# Altium schematic sheet [03] - MCU and Conn.SchDoc
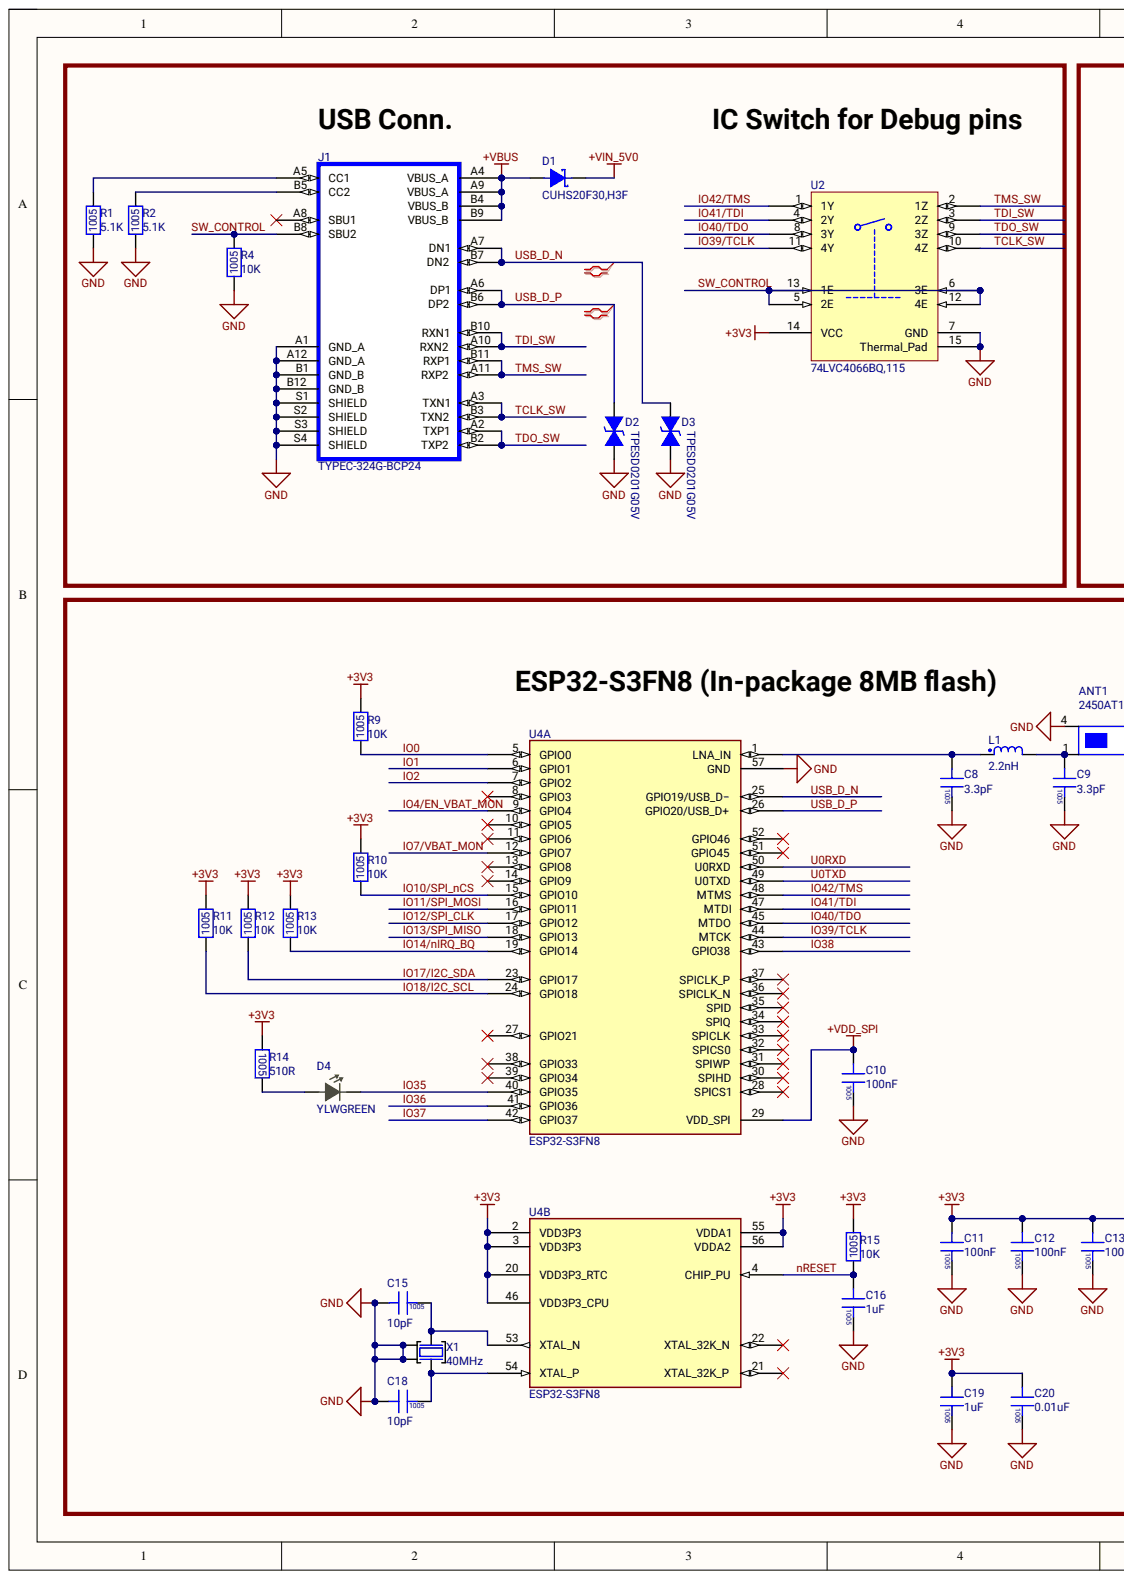
[diagram p0: [03] - MCU and Conn.SchDoc, left]
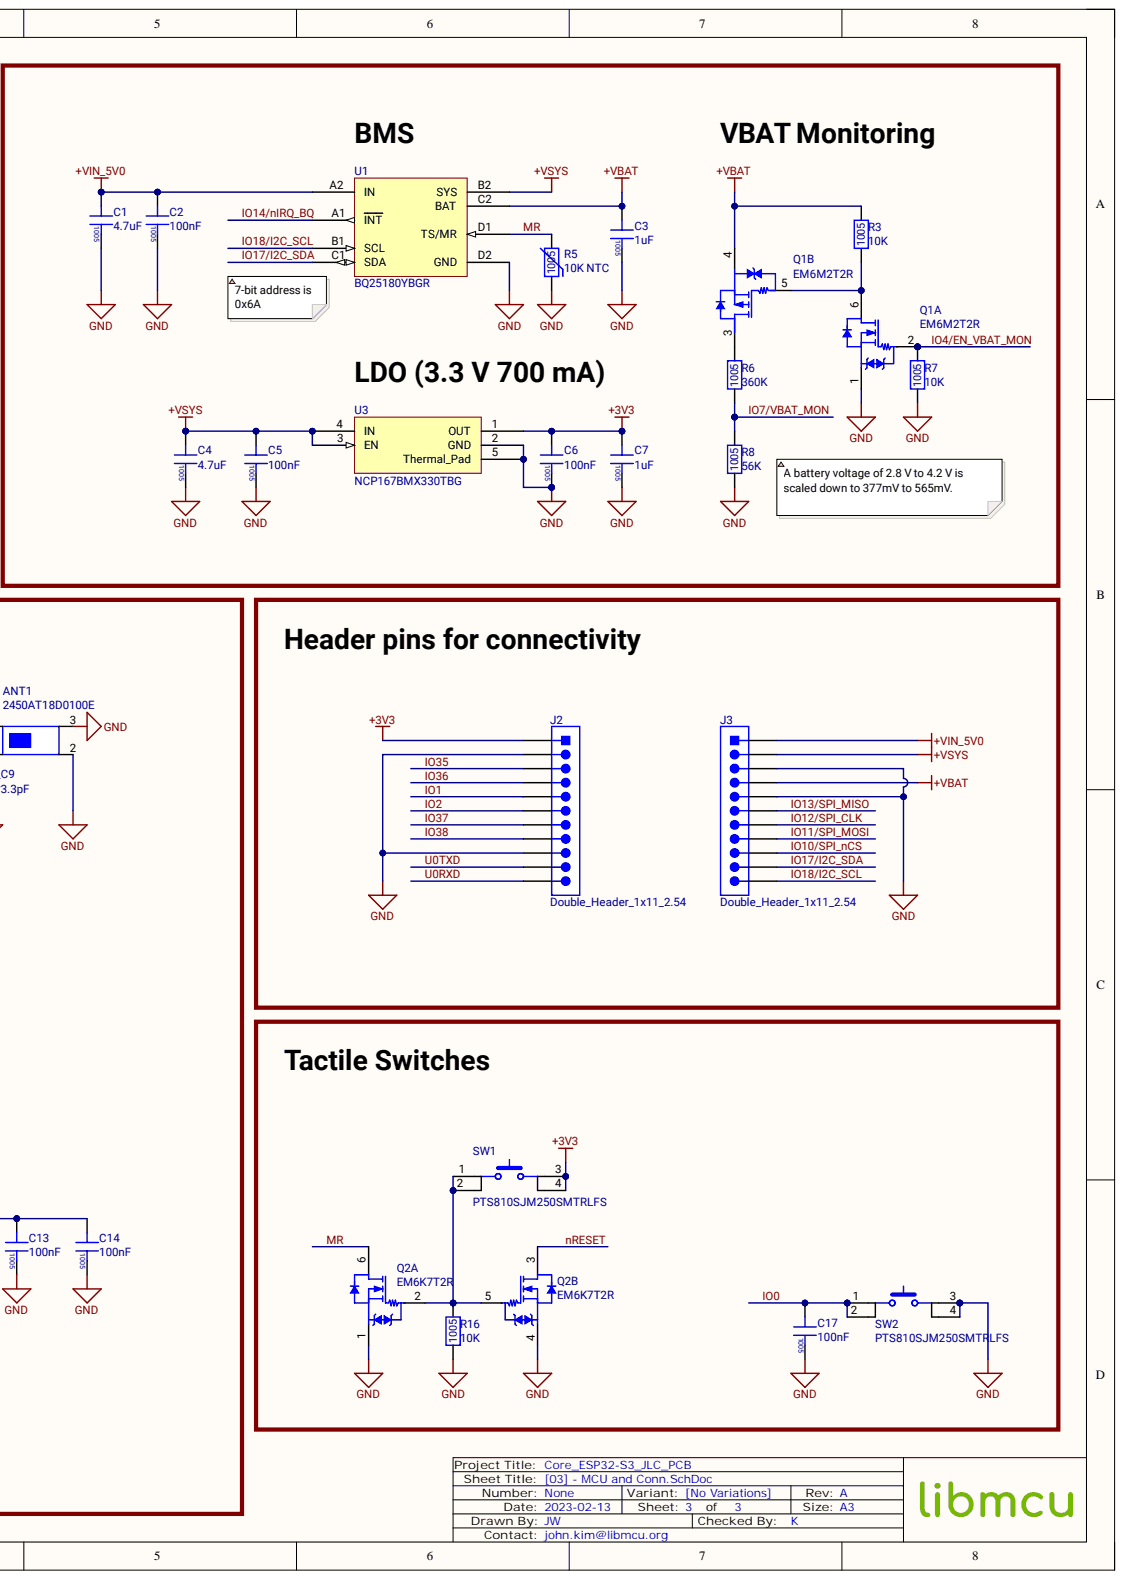
[diagram p1: [03] - MCU and Conn.SchDoc, right]

## Parts
ANT1  2450AT18D0100E  [2450AT18D0100E]
C1  4.7uF  Case Code (Metric): 1005  [CAPC1005X06N]
C2  100nF  Case Code (Metric): 1005  [CAPC1005X06N]
C3  1uF  Case Code (Metric): 1005  [CAPC1005X06N]
C4  4.7uF  Case Code (Metric): 1005  [CAPC1005X06N]
C5  100nF  Case Code (Metric): 1005  [CAPC1005X06N]
C6  100nF  Case Code (Metric): 1005  [CAPC1005X06N]
C7  1uF  Case Code (Metric): 1005  [CAPC1005X06N]
C8  3.3pF  Case Code (Metric): 1005  [CAPC1005X06N]
C9  3.3pF  Case Code (Metric): 1005  [CAPC1005X06N]
C10  100nF  Case Code (Metric): 1005  [CAPC1005X06N]
C11  100nF  Case Code (Metric): 1005  [CAPC1005X06N]
C12  100nF  Case Code (Metric): 1005  [CAPC1005X06N]
C13  100nF  Case Code (Metric): 1005  [CAPC1005X06N]
C14  100nF  Case Code (Metric): 1005  [CAPC1005X06N]
C15  10pF  Case Code (Metric): 1005  [CAPC1005X06N]
C16  1uF  Case Code (Metric): 1005  [CAPC1005X06N]
C17  100nF  Case Code (Metric): 1005  [CAPC1005X06N]
C18  10pF  Case Code (Metric): 1005  [CAPC1005X06N]
C19  1uF  Case Code (Metric): 1005  [CAPC1005X06N]
C20  0.01uF  Case Code (Metric): 1005  [CAPC1005X06N]
D1  CUHS20F30,H3F  [US2H-DIODE]
D2  TPESD0201G05V  [DIOC0603X03N]
D3  TPESD0201G05V  [DIOC0603X03N]
D4  YLWGREEN  [LDIOC1608X08N]
J1  TYPEC-324G-BCP24  [KUSBX-SMT3-CS-BTR_KYC]
J2  Double_Header_1x11_2.54  [1x11_DOUBLE_HEADER_2.54]  (no BOM)
J3  Double_Header_1x11_2.54  [1x11_DOUBLE_HEADER_2.54]  (no BOM)
L1  2.2nH  [INDC1005X05N_MURATA_LQ]
Q1  EM6M2T2R  [SOTFL50P160X55-6N]  (parts A,B)
Q2  EM6K7T2R  [SOTFL50P160X55-6N]  (parts A,B)
R1  5.1K  Case Code (Metric): 1005  [RESC1005X04N]
R2  5.1K  Case Code (Metric): 1005  [RESC1005X04N]
R3  10K  Case Code (Metric): 1005  [RESC1005X04N]
R4  10K  Case Code (Metric): 1005  [RESC1005X04N]
R5  10K NTC  Case Code (Metric): 1005  [NTC1005X05N]
R6  360K  Case Code (Metric): 1005  [RESC1005X04N]
R7  10K  Case Code (Metric): 1005  [RESC1005X04N]
R8  56K  Case Code (Metric): 1005  [RESC1005X04N]
R9  10K  Case Code (Metric): 1005  [RESC1005X04N]
R10  10K  Case Code (Metric): 1005  [RESC1005X04N]
R11  10K  Case Code (Metric): 1005  [RESC1005X04N]
R12  10K  Case Code (Metric): 1005  [RESC1005X04N]
R13  10K  Case Code (Metric): 1005  [RESC1005X04N]
R14  510R  Case Code (Metric): 1005  [RESC1005X04N]
R15  10K  Case Code (Metric): 1005  [RESC1005X04N]
R16  10K  Case Code (Metric): 1005  [RESC1005X04N]
SW1  PTS810SJM250SMTRLFS  [PTS810]
SW2  PTS810SJM250SMTRLFS  [PTS810]
U1  BQ25180YBGR  [BGA8C40P2X4_100X155X50_YBG0008]
U2  74LVC4066BQ,115  [QFN50P250X300X100_HS-15N]
U3  NCP167BMX330TBG  [NCP167]
U4  ESP32-S3FN8  [QFN40P700X700X90_HS-57N]  (parts A,B)
X1  40MHz  [XT2016_ECS]

## Nets
+3V3: C6.2 C7.2 C11.2 C12.2 C13.2 C14.2 C19.2 C20.2 J2.1 R9.2 R10.2 R11.2 R12.2 R13.2 R14.2 R15.2 SW1.3 SW1.4 U2.14(VCC) U3.1(OUT) U4.2(VDD3P3) U4.3(VDD3P3) U4.20(VDD3P3_RTC) U4.46(VDD3P3_CPU) U4.55(VDDA1) U4.56(VDDA2)
+VBAT: C3.2 J3.4 Q1.4 R3.2 U1.C2(BAT)
+VBUS: D1.2 J1.A4(VBUS_A) J1.A9(VBUS_A) J1.B4(VBUS_B) J1.B9(VBUS_B)
+VDD_SPI: C10.2 U4.29(VDD_SPI)
+VIN_5V0: C1.2 C2.2 D1.1 J3.1 U1.A2(IN)
+VSYS: C4.2 C5.2 J3.2 U1.B2(SYS) U3.3(EN) U3.4(IN)
GND: ANT1.2 ANT1.3 ANT1.4 C1.1 C2.1 C3.1 C4.1 C5.1 C6.1 C7.1 C8.1 C9.1 C10.1 C11.1 C12.1 C13.1 C14.1 C15.2 C16.1 C17.1 C18.2 C19.1 C20.1 D2.2 D3.2 J1.A1(GND_A) J1.A12(GND_A) J1.B1(GND_B) J1.B12(GND_B) J1.S1(SHIELD) J1.S2(SHIELD) J1.S3(SHIELD) J1.S4(SHIELD) J2.2 J2.9 J3.3 J3.5 Q1.1 Q2.1 Q2.4 R1.1 R2.1 R4.1 R5.1 R7.1 R8.1 R16.1 SW2.3 SW2.4 U1.D2(GND) U2.7(GND) U2.15(Thermal_Pad) U3.2(GND) U3.5(Thermal_Pad) U4.57(GND) X1.2 X1.4
IO0: C17.2 R9.1 SW2.1 SW2.2 U4.5(GPIO0)
IO1: J2.5 U4.6(GPIO1)
IO2: J2.6 U4.7(GPIO2)
IO4/EN_VBAT_MON: Q1.2 R7.2 U4.9(GPIO4)
IO7/VBAT_MON: R6.1 R8.2 U4.12(GPIO7)
IO10/SPI_nCS: J3.9 R10.1 U4.15(GPIO10)
IO11/SPI_MOSI: J3.8 U4.16(GPIO11)
IO12/SPI_CLK: J3.7 U4.17(GPIO12)
IO13/SPI_MISO: J3.6 U4.18(GPIO13)
IO14/nIRQ_BQ: R13.1 U1.A1(INT) U4.19(GPIO14)
IO17/I2C_SDA: J3.10 R12.1 U1.C1(SDA) U4.23(GPIO17)
IO18/I2C_SCL: J3.11 R11.1 U1.B1(SCL) U4.24(GPIO18)
IO35: D4.K J2.3 U4.40(GPIO35)
IO36: J2.4 U4.41(GPIO36)
IO37: J2.7 U4.42(GPIO37)
IO38: J2.8 U4.43(GPIO38)
IO39/TCLK: U2.11(4Y) U4.44(MTCK)
IO40/TDO: U2.8(3Y) U4.45(MTDO)
IO41/TDI: U2.4(2Y) U4.47(MTDI)
IO42/TMS: U2.1(1Y) U4.48(MTMS)
MR: Q2.6 R5.2 U1.D1(TS/MR)
nRESET: C16.2 Q2.3 R15.1 U4.4(CHIP_PU)
SW_CONTROL: J1.B8(SBU2) R4.2 U2.5(2E) U2.6(3E) U2.12(4E) U2.13(1E)
TCLK_SW: J1.A3(TXN1) J1.B3(TXN2) U2.10(4Z)
TDI_SW: J1.A10(RXN2) J1.B10(RXN1) U2.3(2Z)
TDO_SW: J1.A2(TXP1) J1.B2(TXP2) U2.9(3Z)
TMS_SW: J1.A11(RXP2) J1.B11(RXP1) U2.2(1Z)
U0RXD: J2.11 U4.50(U0RXD)
U0TXD: J2.10 U4.49(U0TXD)
USB_D_N: D3.1 J1.A7(DN1) J1.B7(DN2) U4.25(GPIO19/USB_D−)
USB_D_P: D2.1 J1.A6(DP1) J1.B6(DP2) U4.26(GPIO20/USB_D+)
NetANT1_1: ANT1.1 C9.2 L1.2
NetC8_2: C8.2 L1.1 U4.1(LNA_IN)
NetC15_1: C15.1 U4.53(XTAL_N) X1.1
NetC18_1: C18.1 U4.54(XTAL_P) X1.3
NetD4_A: D4.A R14.1
NetJ1_A5: J1.A5(CC1) R1.2
NetJ1_B5: J1.B5(CC2) R2.2
NetQ1_3: Q1.3 R6.2
NetQ1_5: Q1.5 Q1.6 R3.1
NetQ2_2: Q2.2 Q2.5 R16.2 SW1.1 SW1.2

Not connected: J1 A8(SBU1); U4 8(GPIO3) 10(GPIO5) 11(GPIO6) 13(GPIO8) 14(GPIO9) 21(XTAL_32K_P) 22(XTAL_32K_N) 27(GPIO21) 28(SPICS1) 30(SPIHD) 31(SPIWP) 32(SPICS0) 33(SPICLK) 34(SPIQ) 35(SPID) 36(SPICLK_N) 37(SPICLK_P) 38(GPIO33) 39(GPIO34) 51(GPIO45) 52(GPIO46)

## Library data of the parts
ANT1 = 2450AT18D0100E: RF ANT 2.4GHZ CHIP SOLDER SMD; Manufacturer: Johanson; Bandwidth: 100MHz; Frequency: 2.45GHz; Gain: -1dB; Impedance: 50R; Max Power Dissipation: 2W; Number of Bands: 1; Return Loss: -10dB; Case/Package: SMD/SMT; Category: RF Antennas; Component Kind: Standard; ComponentLink1Description: https://datasheet.ciiva.com/29647/2450at18d0100-29647392.pdf?src-supplier=Digi-Key; ComponentLink1URL: https://datasheet.ciiva.com/29647/2450at18d0100-29647392.pdf?src-supplier=Digi-Key; ComponentLink2Description: https://datasheet.ciiva.com/24046/antenna-2450at18d0100-v3-258090-24046431.pdf?src-supplier=Mouser; ComponentLink2URL: https://datasheet.ciiva.com/24046/antenna-2450at18d0100-v3-258090-24046431.pdf?src-supplier=Mouser; Height: 0.047inch; Length: 3.2mm; Library Name: Schlib-master.SchLib; Manufacturer Part Number: 2450AT18D0100E; Mount: Surface Mount; Package Quantity: 3000; Packaging: Tape and Reel; Power Rating: 2W; Pricing 1: 1=0.67000, 10=0.58900, 25=0.55360, 100=0.45190, 250=0.41972, 500=0.35720, 1000=0.28576 (USD); Radiation Hardening: No; RoHS: Yes; RoHS Compliant: Yes; Series: -; Stock 1: 488138; Supplier 1: Digi-Key; Supplier Part Number 1: 712-1573-1-ND; Termination: Solder; Width: 1.6mm
C1 C4 = CL05A475MP5NRNC: CAP CER 4.7UF 10V X5R 0402; Manufacturer: Samsung; Tolerance: 20%; Capacitance: 4.7uF; Case Code (Imperial): 0402; Case/Package: 0402; Category: Ceramic Capacitors; Component Kind: Standard; ComponentLink1Description: https://datasheet.ciiva.com/25786/cl05a475mp5nrnc-25786963.pdf?src-supplier=Digi-Key; ComponentLink1URL: https://datasheet.ciiva.com/25786/cl05a475mp5nrnc-25786963.pdf?src-supplier=Digi-Key; ComponentLink2Description: https://datasheet.ciiva.com/16437/cl05b104kq5nnnc-16437353.pdf?src-supplier=Future+Electronics; ComponentLink2URL: https://datasheet.ciiva.com/16437/cl05b104kq5nnnc-16437353.pdf?src-supplier=Future+Electronics; Dielectric: X5R; Lead Free: Lead Free; Length: 0.039inch; Library Name: Schlib-master.SchLib; Manufacturer Part Number: CL05A475MP5NRNC; Max Operating Temperature: 85°C; Min Operating Temperature: -55°C; Mount: Surface Mount; Package Quantity: 10000; Packaging: Tape and Reel; Pricing 1: 1=0.10000, 10=0.06800, 50=0.03680, 100=0.03010, 500=0.02150, 1000=0.01689, 2500=0.01535, 5000=0.01412 (USD); RoHS: Yes; RoHS Compliant: Yes; Series: CL; Stock 1: 6997940; Supplier 1: Digi-Key; Supplier Part Number 1: 1276-1482-1-ND; Thickness: 0.022inch; Voltage Rating: 10V; Width: 0.02inch
C2 C5 C6 C10-C14 C17 = GRM155R71H104KE14D: CAP CER 0.1UF 50V X7R 0402; Manufacturer: Murata; Dielectric Material: Ceramic; Dissipation Factor: 0.025%; Tolerance: 10%; Capacitance: 100nF; Case Code (Imperial): 0402; Case/Package: 0402; Category: Ceramic Capacitors; Component Kind: Standard; ComponentLink1Description: http://www.mouser.com/ds/2/281/c02e-2905.pdf?src-supplier=Mouser; ComponentLink1URL: http://www.mouser.com/ds/2/281/c02e-2905.pdf?src-supplier=Mouser; ComponentLink2Description: http://www.farnell.com/datasheets/2079156.pdf?src-supplier=Farnell; ComponentLink2URL: http://www.farnell.com/datasheets/2079156.pdf?src-supplier=Farnell; ComponentLink3Description: http://components.arrow.com/part/dataview/0323_07030614?src-supplier=Arrow+Europe; ComponentLink3URL: http://components.arrow.com/part/dataview/0323_07030614?src-supplier=Arrow+Europe; ComponentLink4Description: http://psearch.en.murata.com/capacitor/product/GRM155R71H104KE14%23.pdf?src-supplier=Avnet; ComponentLink4URL: http://psearch.en.murata.com/capacitor/product/GRM155R71H104KE14%23.pdf?src-supplier=Avnet; ComponentLink5Description: https://search.murata.co.jp/Ceramy/image/img/A01X/G101/ENG/GRM155R71H104KE14-01.pdf?src-supplier=Digi-Key; ComponentLink5URL: https://search.murata.co.jp/Ceramy/image/img/A01X/G101/ENG/GRM155R71H104KE14-01.pdf?src-supplier=Digi-Key; ComponentLink6Description: http://www.farnell.com/datasheets/2079156.pdf?src-supplier=Newark; ComponentLink6URL: http://www.farnell.com/datasheets/2079156.pdf?src-supplier=Newark; ComponentLink7Description: http://www.farnell.com/datasheets/2079156.pdf?src-supplier=Element14; ComponentLink7URL: http://www.farnell.com/datasheets/2079156.pdf?src-supplier=Element14; Depth: 0.5mm; Dielectric: X7R; Height: 0.5mm; Lead Free: Lead Free; Length: 1mm; Library Name: Schlib-master.SchLib; Manufacturer Part Number: GRM155R71H104KE14D; Material: Ceramic; Max Operating Temperature: 125°C; Min Operating Temperature: -55°C; Mount: Surface Mount; Package Quantity: 10000; Packaging: Tape and Reel; Pricing 1: 1=0.10000, 10=0.03400, 50=0.01860, 100=0.01520, 500=0.01086, 1000=0.00854, 2500=0.00776, 5000=0.00714 (USD); REACH SVHC: No SVHC; Resistor Type: Ceramic; RoHS: Yes; RoHS Compliant: Yes; Stock 1: 23318607; Supplier 1: Digi-Key; Supplier Part Number 1: 490-10700-1-ND; Termination: SMD/SMT; Thickness: 0.022inch; Voltage: 50V; Voltage Rating: 50V; Voltage Rating (DC): 50V; Weight: 0.000212oz; Width: 0.5mm
C3 C7 C16 C19 = CL05A105KO5NNNC: CAP CER 1UF 16V X5R 0402; Manufacturer: Samsung; Tolerance: 10%; Capacitance: 1uF; Case Code (Imperial): 0402; Case/Package: 0402; Category: Ceramic Capacitors; Component Kind: Standard; ComponentLink1Description: https://datasheet.ciiva.com/16207/cl05a105ko5nnnc-16207571.pdf?src-supplier=Future+Electronics; ComponentLink1URL: https://datasheet.ciiva.com/16207/cl05a105ko5nnnc-16207571.pdf?src-supplier=Future+Electronics; ComponentLink2Description: https://datasheet.ciiva.com/15195/getdatasheetpartid-355272-15195629.pdf?src-supplier=Verical; ComponentLink2URL: https://datasheet.ciiva.com/15195/getdatasheetpartid-355272-15195629.pdf?src-supplier=Verical; Contact Plating: Tin; Depth: 0.5mm; Dielectric: X5R; Height: 0.5mm; Length: 1mm; Library Name: Schlib-master.SchLib; Manufacturer Part Number: CL05A105KO5NNNC; Max Operating Temperature: 85°C; Min Operating Temperature: -55°C; Mount: Surface Mount; Package Quantity: 1; Packaging: Tape and Reel; Pricing 1: 1=0.10000, 10=0.04400, 50=0.02400, 100=0.01960, 500=0.01402, 1000=0.01101, 2500=0.01001, 5000=0.00921 (USD); Radiation Hardening: No; RoHS: Yes; Stock 1: 2455652; Supplier 1: Digi-Key; Supplier Part Number 1: 1276-1067-1-ND; Thickness: 0.022inch; Voltage: 16V; Voltage Rating: 16V; Width: 0.02inch
C8 C9 = GJM1555C1H3R3BB01D: CAP CER 3.3PF 50V C0G/NP0 0402; Manufacturer: Murata; Dielectric: C0G; Case Code (Imperial): 0402; Case/Package: 0402; Category: Ceramic Capacitors; Component Kind: Standard; ComponentLink1Description: https://datasheet.ciiva.com/8030/1700175-8030120.pdf?src-supplier=Element14; ComponentLink1URL: https://datasheet.ciiva.com/8030/1700175-8030120.pdf?src-supplier=Element14; ComponentLink2Description: https://datasheet.ciiva.com/11614/product-11614199.pdf?src-supplier=Avnet; ComponentLink2URL: https://datasheet.ciiva.com/11614/product-11614199.pdf?src-supplier=Avnet; Construction: Flat; Depth: 0.5mm; Height: 0.5mm; Lead Free: Lead Free; Lead Pitch: 0.3mm; Length: 1mm; Library Name: Schlib-master.SchLib; Manufacturer Part Number: GJM1555C1H3R3BB01D; Max Operating Temperature: 125°C; Min Operating Temperature: -55°C; Mounting Technology: Surface Mount; Package Quantity: 1; Packaging: Tape and Reel; Pins: 2; Pricing 1: 1=0.18000, 10=0.12800, 50=0.07260, 100=0.06020, 500=0.04220, 1000=0.03494, 2500=0.03252, 5000=0.02975 (USD); Radiation Hardening: No; Reach SVHC Compliant: Yes; RoHS: Yes; RoHS Compliant: Yes; Series: GJM; Stock 1: 241873; Supplier 1: Digi-Key; Supplier Part Number 1: 490-8097-1-ND; Termination: SMD/SMT; Thickness: 0.022inch; Value: 3.3pF; Voltage: 50V; Voltage Rating: 50V; Voltage Rating (DC): 50V; Width: 0.5mm
C15 C18 = CL05C100DB5NNNC: CAP CER 10PF 50V C0G/NP0 0402; Manufacturer: Samsung; Category 1: Capacitors; Category 2: Ceramic Capacitors; Dielectric: C0G; Capacitance: 10pF; Case Code (Imperial): 0402; Case/Package: 0402; Component Kind: Standard; ComponentLink1Description: https://datasheet.ciiva.com/25805/cl05c100db5nnnc-25805476.pdf?src-supplier=Digi-Key; ComponentLink1URL: https://datasheet.ciiva.com/25805/cl05c100db5nnnc-25805476.pdf?src-supplier=Digi-Key; ComponentLink2Description: https://datasheet.ciiva.com/16427/cl05c100db5nnnc-16427321.pdf?src-supplier=Future+Electronics; ComponentLink2URL: https://datasheet.ciiva.com/16427/cl05c100db5nnnc-16427321.pdf?src-supplier=Future+Electronics; Depth: 0.5mm; Height: 0.5mm; Lead Free: Lead Free; Length: 1mm; Library Name: Schlib-master.SchLib; Manufacturer 1: Samsung; Manufacturer Part Number: CL05C100DB5NNNC; Manufacturer Part Number 1: CL05C100DB5NNNC; Max Operating Temperature: 125°C; Min Operating Temperature: -55°C; Mount: Surface Mount; Package Quantity: 1; Packaging: Tape and Reel; Pricing 1: 1=0.10000, 10=0.03800, 100=0.01680, 500=0.01198, 1000=0.00941, 2500=0.00855, 5000=0.00787 (USD); Radiation Hardening: No; RoHS: Yes; Stock 1: 197409; Supplier 1: Digi-Key; Supplier Part Number 1: 1276-1625-1-ND; Thickness: 0.022inch; Voltage Rating: 50V; Voltage Rating (DC): 50V; Width: 0.02inch
C20 = CL05B103KA5NNNC: CAP CER 10000PF 25V X7R 0402; Manufacturer: Samsung; Capacitance: 10nF; Category 1: Capacitors; Category 2: Ceramic Capacitors; Tolerance: 10%; Case Code (Imperial): 0402; Case/Package: 0402; Category: Ceramic Capacitors; Component Kind: Standard; ComponentLink1Description: https://datasheet.ciiva.com/15195/getdatasheetpartid-358612-15195635.pdf?src-supplier=Verical; ComponentLink1URL: https://datasheet.ciiva.com/15195/getdatasheetpartid-358612-15195635.pdf?src-supplier=Verical; ComponentLink2Description: https://datasheet.ciiva.com/16740/cl05b104kq5nnnc-16740683.pdf?src-supplier=Future+Electronics; ComponentLink2URL: https://datasheet.ciiva.com/16740/cl05b104kq5nnnc-16740683.pdf?src-supplier=Future+Electronics; Contact Plating: Tin; Depth: 0.5mm; Dielectric: X7R; Height: 0.5mm; Length: 1mm; Library Name: Schlib-master.SchLib; Manufacturer Part Number: CL05B103KA5NNNC; Max Operating Temperature: 125°C; Min Operating Temperature: -55°C; Mount: Surface Mount; Package Quantity: 1; Packaging: Tape and Reel; Pricing 1: 1=0.10000, 10=0.02100, 100=0.00930, 500=0.00666, 1000=0.00523, 2500=0.00476, 5000=0.00438 (USD); Radiation Hardening: No; RoHS: Yes; Stock 1: 2957715; Supplier 1: Digi-Key; Supplier Part Number 1: 1276-1057-1-ND; Thickness: 0.022inch; Voltage Rating: 25V; Width: 0.02inch
D1 = CUHS20F30,H3F: DIODE SCHOTTKY 30V 2A US2H; Manufacturer: Toshiba; Component Kind: Standard; Library Name: Schlib-master.SchLib; Manufacturer Part Number: CUHS20F30,H3F; Pricing 1: 1=0.37000, 10=0.30200, 100=0.20540, 500=0.15408, 1000=0.11556 (USD); RoHS: No; Stock 1: 70970; Supplier 1: Digi-Key; Supplier Part Number 1: CUHS20F30H3FCT-ND
D2 D3 = TPESD0201G05V: TVS DIODE 5.5VWM 40VC 0201; Manufacturer: TECH PUBLIC; Clamping Voltage: 40V; Number of Bidirectional Channels: 1; Peak Pulse Current: 3A; Power Line Protection: No; Reverse Standoff Voltage: 5.5V; Case/Package: SMD/SMT; Category: Diodes; Component Kind: Standard; ComponentLink1Description: https://datasheet.lcsc.com/lcsc/2005211235_TECH-PUBLIC-TPESD0201G15V_C558460.pdf; ComponentLink1URL: https://datasheet.lcsc.com/lcsc/2005211235_TECH-PUBLIC-TPESD0201G15V_C558460.pdf; Halogen Free: Halogen Free; Lead Free: Lead Free; Library Name: Schlib-master.SchLib; Manufacturer Part Number: TPESD0201G05V; Max Operating Temperature: 125°C; Min Operating Temperature: -55°C; Mount: Surface Mount; Packaging: Tape and Reel; Resistor Type: Zener; RoHS: Yes; RoHS Compliant: Yes
D4 = 19-217/G7C-AN1P2/3T: LED YLW/GREEN DIFFUSED 1608 SMD; Manufacturer: Everlight; Color: Green,Yellow; Forward Voltage: 2V; Lens Style: Clear,Diffused,Colorless,Rectangular; Number of LEDs: 1; Viewing Angle: 120°; Category: LEDs; Component Kind: Standard; ComponentLink1Description: https://datasheet.ciiva.com/48679/19-217-g7c-an1p2-3t-48679179.pdf?src-supplier=Avnet; ComponentLink1URL: https://datasheet.ciiva.com/48679/19-217-g7c-an1p2-3t-48679179.pdf?src-supplier=Avnet; Lead Free: Lead Free; Library Name: Schlib-master.SchLib; Manufacturer Part Number: 19-217/G7C-AN1P2/3T; Mounting Technology: Surface Mount; Pins: 2; Pricing 1: 2635=0.01900, 6250=0.01600, 10000=0.01500, 13335=0.01500, 17860=0.01400, 23080=0.01300 (USD); RoHS: Yes; RoHS Compliant: Yes; Stock 1: 152500; Supplier 1: Win Source Electronics; Supplier Part Number 1: 5203-19-217/G7C-AN1P2/3T
J1 = TYPEC-324G-BCP24: CONN RCPT USB TYPE C, SMT PINS, TH SHIELD; Manufacturer: XUNPU; Category: USB Connectors; Component Kind: Standard; ComponentLink1Description: https://www.lcsc.com/product-detail/USB-Connectors_XUNPU-TYPEC-324G-BCP24_C920230.html; ComponentLink1URL: https://www.lcsc.com/product-detail/USB-Connectors_XUNPU-TYPEC-324G-BCP24_C920230.html; Library Name: Schlib-master.SchLib; Manufacturer Part Number: TYPEC-324G-BCP24
L1 = LQG15HS2N2S02D: FIXED IND 2.2NH 900MA 120MOHM SM; Manufacturer: Murata; Core Material: Air; Current Rating: 300mA; DC Resistance (DCR): 120mR; Q Factor: 8; Self Resonant Frequency: 6GHz; Shielding: Unshielded; Test Frequency: 100MHz; Case Code (Imperial): 0402; Case Code (Metric): 1005; Case/Package: 0402; Category: Fixed Inductors; Component Kind: Standard; ComponentLink1Description: https://datasheet.ciiva.com/11799/0900766b8090d685-11799036.pdf?src-supplier=RSComponents; ComponentLink1URL: https://datasheet.ciiva.com/11799/0900766b8090d685-11799036.pdf?src-supplier=RSComponents; ComponentLink2Description: https://datasheet.ciiva.com/1038/l0075s0083lqg15hs-1038149.pdf?src-supplier=Digi-Key; ComponentLink2URL: https://datasheet.ciiva.com/1038/l0075s0083lqg15hs-1038149.pdf?src-supplier=Digi-Key; ComponentLink3Description: https://datasheet.ciiva.com/1038/l0075s0083lqg15hs-1038148.pdf?src-supplier=Digi-Key; ComponentLink3URL: https://datasheet.ciiva.com/1038/l0075s0083lqg15hs-1038148.pdf?src-supplier=Digi-Key; ComponentLink4Description: https://datasheet.ciiva.com/1038/l0075s0083lqg15hs-1038150.pdf?src-supplier=Digi-Key; ComponentLink4URL: https://datasheet.ciiva.com/1038/l0075s0083lqg15hs-1038150.pdf?src-supplier=Digi-Key; ComponentLink5Description: https://datasheet.ciiva.com/8510/l0075s0083lqg15hs-8510846.pdf?src-supplier=Avnet; ComponentLink5URL: https://datasheet.ciiva.com/8510/l0075s0083lqg15hs-8510846.pdf?src-supplier=Avnet; ComponentLink6Description: https://datasheet.ciiva.com/16444/l0075s0083lqg15hs-16444395.pdf?src-supplier=Future+Electronics; ComponentLink6URL: https://datasheet.ciiva.com/16444/l0075s0083lqg15hs-16444395.pdf?src-supplier=Future+Electronics; DC Current: 300mA; Depth: 0.55mm; Height: 0.5mm; Height - Seated (Max): 0.022inch; Lead Free: Lead Free; Length: 1mm; Library Name: Schlib-master.SchLib; Manufacturer Part Number: LQG15HS2N2S02D; Max Current Rating: 300mA; Max DC Current: 300mA; Max Operating Temperature: 125°C; Military Standard: Not; Min Operating Temperature: -55°C; Mounting Technology: Surface Mount; Package Quantity: 10000; Packaging: Tape and Reel; Pricing 1: 1=0.10000, 10=0.05300, 25=0.04720, 50=0.04020, 100=0.03550, 250=0.03248, 500=0.02954, 1000=0.02482, 2500=0.02127 (USD); Radiation Hardening: No; RoHS: Yes; RoHS Compliant: Yes; Series: LQG15HS; Stock 1: 110277; Supplier 1: Digi-Key; Supplier Part Number 1: 490-2614-1-ND; Termination: SMD/SMT; Value: 2.2nH; Width: 0.02inch
Q1 = EM6M2T2R: MOSFET N/P-CH 20V 0.2A EMT6; Manufacturer: Rohm; Continuous Drain Current (ID): 200mA; Drain to Source Resistance: 1R; FET Type: N and P-Channel; Input Capacitance: 25pF; Max Power Dissipation: 150mW; Case/Package: SOT-23-5; Category: MOSFETs; Component Kind: Standard; ComponentLink1Description: https://datasheet.ciiva.com/6387/em6m2-6387413.pdf?src-supplier=Digi-Key; ComponentLink1URL: https://datasheet.ciiva.com/6387/em6m2-6387413.pdf?src-supplier=Digi-Key; ComponentLink2Description: https://datasheet.ciiva.com/6387/em6m2-6387415.pdf?src-supplier=Digi-Key; ComponentLink2URL: https://datasheet.ciiva.com/6387/em6m2-6387415.pdf?src-supplier=Digi-Key; ComponentLink3Description: https://datasheet.ciiva.com/6387/em6m2-6387417.pdf?src-supplier=Digi-Key; ComponentLink3URL: https://datasheet.ciiva.com/6387/em6m2-6387417.pdf?src-supplier=Digi-Key; Drain to Source Voltage (Vdss): 20V; Drain-source On Resistance-Max: 1R; Lead Free: Lead Free; Library Name: Schlib-master.SchLib; Manufacturer Part Number: EM6M2T2R; Max Operating Temperature: 150°C; Min Operating Temperature: -55°C; Mounting Technology: Surface Mount; Package Quantity: 1; Packaging: Tape and Reel; Pins: 6; Pricing 1: 1=0.58000, 10=0.49600, 100=0.37030, 500=0.29096, 1000=0.22482, 2000=0.20499 (USD); Radiation Hardening: No; Rds On Max: 1R; RoHS: Yes; RoHS Compliant: Yes; Stock 1: 90900; Supplier 1: Digi-Key; Supplier Part Number 1: EM6M2T2RCT-ND
Q2 = EM6K7T2R: MOSFET 2N-CH 20V 0.2A EMT6; Manufacturer: Rohm; Continuous Drain Current (ID): 200mA; Drain to Source Resistance: 1.2R; Fall Time: 10ns; FET Type: 2 N-Channel; Gate to Source Voltage (Vgs): 8V; Input Capacitance: 25pF; Max Power Dissipation: 150mW; Turn-Off Delay Time: 15ns; Turn-On Delay Time: 5ns; Category: MOSFETs; Component Kind: Standard; ComponentLink1Description: https://datasheet.ciiva.com/6387/em6k7-6387446.pdf?src-supplier=Digi-Key; ComponentLink1URL: https://datasheet.ciiva.com/6387/em6k7-6387446.pdf?src-supplier=Digi-Key; ComponentLink2Description: https://datasheet.ciiva.com/13051/em6k7-209726-13051863.pdf?src-supplier=Mouser; ComponentLink2URL: https://datasheet.ciiva.com/13051/em6k7-209726-13051863.pdf?src-supplier=Mouser; ComponentLink3Description: https://datasheet.ciiva.com/11162/em6k7-11162107.pdf?src-supplier=Avnet; ComponentLink3URL: https://datasheet.ciiva.com/11162/em6k7-11162107.pdf?src-supplier=Avnet; ComponentLink4Description: https://datasheet.ciiva.com/6387/em6k7-6387451.pdf?src-supplier=Digi-Key; ComponentLink4URL: https://datasheet.ciiva.com/6387/em6k7-6387451.pdf?src-supplier=Digi-Key; ComponentLink5Description: https://datasheet.ciiva.com/16437/em6k7-16437677.pdf?src-supplier=Future+Electronics; ComponentLink5URL: https://datasheet.ciiva.com/16437/em6k7-16437677.pdf?src-supplier=Future+Electronics; Drain to Source Voltage (Vdss): 20V; Drain-source On Resistance-Max: 1.2R; Lead Free: Lead Free; Library Name: Schlib-master.SchLib; Manufacturer Part Number: EM6K7T2R; Max Operating Temperature: 150°C; Min Operating Temperature: -55°C; Mounting Technology: Surface Mount; Package Quantity: 8000; Packaging: Tape and Reel; Pins: 6; Pricing 1: 1=0.54000, 10=0.43900, 100=0.29900, 500=0.22428, 1000=0.16821, 2000=0.15419 (USD); Radiation Hardening: No; Rds On Max: 1.2R; Rise Time: 10ns; RoHS: Yes; RoHS Compliant: Yes; Stock 1: 15154; Supplier 1: Digi-Key; Supplier Part Number 1: EM6K7T2RCT-ND
R1 R2 = RC0402FR-075K1L: RES SMD 5.1K OHM 1% 1/16W 0402; Manufacturer: Yageo; Max Power Dissipation: 63mW; Resistance: 5.1kR; Temperature Coefficient: 100ppm/°C; Voltage Rating: 50V; Case Code (Imperial): 0402; Case/Package: 0402; Category: Chip SMD Resistors; Component Kind: Standard; ComponentLink1Description: https://datasheet.ciiva.com/2453/pyu-r-int-thick-7-2453400.pdf?src-supplier=Digi-Key; ComponentLink1URL: https://datasheet.ciiva.com/2453/pyu-r-int-thick-7-2453400.pdf?src-supplier=Digi-Key; ComponentLink2Description: https://datasheet.ciiva.com/2453/pyu-r-int-thick-7-2453401.pdf?src-supplier=Digi-Key; ComponentLink2URL: https://datasheet.ciiva.com/2453/pyu-r-int-thick-7-2453401.pdf?src-supplier=Digi-Key; ComponentLink3Description: https://datasheet.ciiva.com/2453/pyu-r-int-thick-7-2453402.pdf?src-supplier=Digi-Key; ComponentLink3URL: https://datasheet.ciiva.com/2453/pyu-r-int-thick-7-2453402.pdf?src-supplier=Digi-Key; ComponentLink4Description: https://datasheet.ciiva.com/12558/pyu-rc0402-51-rohs-l-6-r-8721-12558460.pdf?src-supplier=Mouser; ComponentLink4URL: https://datasheet.ciiva.com/12558/pyu-rc0402-51-rohs-l-6-r-8721-12558460.pdf?src-supplier=Mouser; ComponentLink5Description: https://datasheet.ciiva.com/16744/pyu-rc0402-51-rohs-l-6-r-16744283.pdf?src-supplier=Future+Electronics; ComponentLink5URL: https://datasheet.ciiva.com/16744/pyu-rc0402-51-rohs-l-6-r-16744283.pdf?src-supplier=Future+Electronics; ComponentLink6Description: https://datasheet.ciiva.com/15189/getdatasheetpartid-323636-15189493.pdf?src-supplier=Verical; ComponentLink6URL: https://datasheet.ciiva.com/15189/getdatasheetpartid-323636-15189493.pdf?src-supplier=Verical; ComponentLink7Description: https://datasheet.ciiva.com/7983/1018594-7983519.pdf?src-supplier=Element14; ComponentLink7URL: https://datasheet.ciiva.com/7983/1018594-7983519.pdf?src-supplier=Element14; ComponentLink8Description: https://datasheet.ciiva.com/46394/1018594-46394936.pdf?src-supplier=Element14; ComponentLink8URL: https://datasheet.ciiva.com/46394/1018594-46394936.pdf?src-supplier=Element14; Construction: Rectangular; Depth: 0.5mm; Features: Moisture Resistant; Height: 0.016inch; Lead Free: Lead Free; Length: 1mm; Library Name: Schlib-master.SchLib; Manufacturer Part Number: RC0402FR-075K1L; Max Operating Temperature: 155°C; Military Standard: Not; Min Operating Temperature: -55°C; Number of Terminations: 2; Package Quantity: 1; Packaging: Tape and Reel; Power Rating: 63mW; Pricing 1: 1=0.10000, 10=0.01600, 100=0.00660, 1000=0.00299, 2500=0.00259, 5000=0.00214 (USD); REACH SVHC: Unknown; Resistance Tolerance: 1%; Resistor Type: Thick Film; RoHS: Yes; RoHS Compliant: Yes; Series: RC; Stock 1: 1329359; Supplier 1: Digi-Key; Supplier Part Number 1: 311-5.10KLRCT-ND; Technology: THICK FILM; Termination: SMD/SMT; Tolerance: 1%; Voltage Rating (DC): 50V; Width: 0.02inch; Working Voltage: 50V
R3 R4 R7 R9-R13 R15 R16 = RC0402FR-0710KL: RES SMD 10K OHM 1% 1/16W 0402; Manufacturer: Yageo; Max Power Dissipation: 63mW; Power Rating: 62.5mW; Resistance: 10kR; Temperature Coefficient: 100ppm/°C; Voltage Rating: 50V; Case Code (Imperial): 0402; Case/Package: 0402; Category: Chip SMD Resistors; Component Kind: Standard; ComponentLink1Description: https://datasheet.ciiva.com/7660/38568-7660787.pdf?src-supplier=Element14; ComponentLink1URL: https://datasheet.ciiva.com/7660/38568-7660787.pdf?src-supplier=Element14; ComponentLink2Description: https://datasheet.ciiva.com/7983/1018594-7983486.pdf?src-supplier=Element14; ComponentLink2URL: https://datasheet.ciiva.com/7983/1018594-7983486.pdf?src-supplier=Element14; ComponentLink3Description: https://datasheet.ciiva.com/7849/1784430-7849813.pdf?src-supplier=Element14; ComponentLink3URL: https://datasheet.ciiva.com/7849/1784430-7849813.pdf?src-supplier=Element14; ComponentLink4Description: https://datasheet.ciiva.com/7849/1686128-7849814.pdf?src-supplier=Element14; ComponentLink4URL: https://datasheet.ciiva.com/7849/1686128-7849814.pdf?src-supplier=Element14; ComponentLink5Description: https://datasheet.ciiva.com/7849/1784430-7849815.pdf?src-supplier=Element14; ComponentLink5URL: https://datasheet.ciiva.com/7849/1784430-7849815.pdf?src-supplier=Element14; ComponentLink6Description: https://datasheet.ciiva.com/22747/1018594-22747512.pdf?src-supplier=Element14; ComponentLink6URL: https://datasheet.ciiva.com/22747/1018594-22747512.pdf?src-supplier=Element14; ComponentLink7Description: https://datasheet.ciiva.com/12667/pyu-rc0402-51-rohs-l-6-r-12667067.pdf?src-supplier=Mouser; ComponentLink7URL: https://datasheet.ciiva.com/12667/pyu-rc0402-51-rohs-l-6-r-12667067.pdf?src-supplier=Mouser; Construction: Rectangular; Depth: 0.5mm; Features: Moisture Resistant; Height: 0.32mm; Lead Free: Lead Free; Lead Pitch: 0.2mm; Length: 1mm; Library Name: Schlib-master.SchLib; Manufacturer Part Number: RC0402FR-0710KL; Max Operating Temperature: 155°C; Military Standard: Not; Min Operating Temperature: -55°C; Number of Pins: 2; Number of Terminations: 2; Packaging: Tape and Reel; Pricing 1: 1=0.10000, 10=0.01400, 100=0.00580, 1000=0.00260, 2500=0.00226, 5000=0.00186 (USD); REACH SVHC: No SVHC; Resistance Tolerance: 1%; Resistor Type: Thick Film; RoHS: Yes; RoHS Compliant: Yes; Series: RC; Stock 1: 39209283; Supplier 1: Digi-Key; Supplier Part Number 1: 311-10.0KLRCT-ND; Termination: SMD/SMT; Tolerance: 1%; Voltage Rating (DC): 50V; Width: 0.5mm; Working Voltage: 50V
R5 = NCP15XH103J03RC: THERMISTOR NTC 10KOHM 3380K 0402; Manufacturer: Murata; Accuracy: 0.7%; Category 1: Sensors, Transducers; Category 2: Temperature Sensors - NTC Thermistors; Current Rating: 310uA; Max Power Dissipation: 100mW; Resistance: 10kR; Tolerance: 5%; Case Code (Imperial): 0402; Case/Package: 0402; Category: Thermistors; Component Kind: Standard; ComponentLink1Description: https://datasheet.ciiva.com/22712/1825737-22712084.pdf?src-supplier=Element14; ComponentLink1URL: https://datasheet.ciiva.com/22712/1825737-22712084.pdf?src-supplier=Element14; ComponentLink2Description: https://datasheet.ciiva.com/11822/0900766b807f1e39-11822074.pdf?src-supplier=RSComponents; ComponentLink2URL: https://datasheet.ciiva.com/11822/0900766b807f1e39-11822074.pdf?src-supplier=RSComponents; ComponentLink3Description: https://datasheet.ciiva.com/29387/506183-da-01-en-thermistor-murata-ncp15xh103j03rc-29387260.pdf?src-supplier=Conrad; ComponentLink3URL: https://datasheet.ciiva.com/29387/506183-da-01-en-thermistor-murata-ncp15xh103j03rc-29387260.pdf?src-supplier=Conrad; ComponentLink4Description: https://datasheet.ciiva.com/2848/r44e-2848211.pdf?src-supplier=Digi-Key; ComponentLink4URL: https://datasheet.ciiva.com/2848/r44e-2848211.pdf?src-supplier=Digi-Key; ComponentLink5Description: https://datasheet.ciiva.com/2848/r44e-2848212.pdf?src-supplier=Digi-Key; ComponentLink5URL: https://datasheet.ciiva.com/2848/r44e-2848212.pdf?src-supplier=Digi-Key; ComponentLink6Description: https://datasheet.ciiva.com/2848/r44e-2848213.pdf?src-supplier=Digi-Key; ComponentLink6URL: https://datasheet.ciiva.com/2848/r44e-2848213.pdf?src-supplier=Digi-Key; ComponentLink7Description: https://datasheet.ciiva.com/3282/l0770e-3282046.pdf?src-supplier=Avnet; ComponentLink7URL: https://datasheet.ciiva.com/3282/l0770e-3282046.pdf?src-supplier=Avnet; Depth: 0.5mm; Height: 0.5mm; Lead Free: Lead Free; Length: 1mm; Library Name: Schlib-master.SchLib; Manufacturer 1: Murata; Manufacturer Part Number: NCP15XH103J03RC; Manufacturer Part Number 1: NCP15XH103J03RC; Max Operating Temperature: 125°C; Min Operating Temperature: -40°C; Mount: Surface Mount; Number of Pins: 2; Package Quantity: 1; Packaging: Tape and Reel; Power Rating: 100mW; Pricing 1: 1=0.12000, 5=0.11600, 10=0.10300, 25=0.09520, 50=0.07600, 100=0.06520, 500=0.05706, 1000=0.04891, 5000=0.04347 (USD); Radiation Hardening: No; REACH SVHC: No SVHC; RoHS: Yes; Stock 1: 295142; Supplier 1: Digi-Key; Supplier Part Number 1: 490-2414-1-ND; Termination: SMD/SMT; Width: 0.5mm
R6 = RC0402FR-07360KL: RES SMD 360K OHM 1% 1/16W 0402; Manufacturer: Yageo; Max Power Dissipation: 63mW; Power Rating: 62.5mW; Temperature Coefficient: 100ppm/°C; Value: 360kR; Voltage Rating: 50V; Case Code (Imperial): 0402; Case/Package: 0402; Category: Chip SMD Resistors; Component Kind: Standard; ComponentLink1Description: https://datasheet.ciiva.com/2453/pyu-r-int-thick-7-2453620.pdf?src-supplier=Digi-Key; ComponentLink1URL: https://datasheet.ciiva.com/2453/pyu-r-int-thick-7-2453620.pdf?src-supplier=Digi-Key; ComponentLink2Description: https://datasheet.ciiva.com/2453/pyu-r-int-thick-7-2453621.pdf?src-supplier=Digi-Key; ComponentLink2URL: https://datasheet.ciiva.com/2453/pyu-r-int-thick-7-2453621.pdf?src-supplier=Digi-Key; ComponentLink3Description: https://datasheet.ciiva.com/2453/pyu-r-int-thick-7-2453619.pdf?src-supplier=Digi-Key; ComponentLink3URL: https://datasheet.ciiva.com/2453/pyu-r-int-thick-7-2453619.pdf?src-supplier=Digi-Key; ComponentLink4Description: https://datasheet.ciiva.com/12561/pyu-rc0402-51-rohs-l-6-r-8721-12561150.pdf?src-supplier=Mouser; ComponentLink4URL: https://datasheet.ciiva.com/12561/pyu-rc0402-51-rohs-l-6-r-8721-12561150.pdf?src-supplier=Mouser; ComponentLink5Description: https://datasheet.ciiva.com/8886/pyu-rc0402-51-rohs-l-6-8886029.pdf?src-supplier=Avnet; ComponentLink5URL: https://datasheet.ciiva.com/8886/pyu-rc0402-51-rohs-l-6-8886029.pdf?src-supplier=Avnet; ComponentLink6Description: https://datasheet.ciiva.com/16742/pyu-rc0402-51-rohs-l-6-r-16742217.pdf?src-supplier=Future+Electronics; ComponentLink6URL: https://datasheet.ciiva.com/16742/pyu-rc0402-51-rohs-l-6-r-16742217.pdf?src-supplier=Future+Electronics; Construction: Rectangular; Contact Plating: Tin,Matte; Depth: 0.5mm; Features: Moisture Resistant; Height: 0.016inch; Lead Free: Lead Free; Length: 1mm; Library Name: Schlib-master.SchLib; Manufacturer Part Number: RC0402FR-07360KL; Max Operating Temperature: 155°C; Military Standard: Not; Min Operating Temperature: -55°C; Number of Terminations: 2; Package Quantity: 1; Packaging: Tape and Reel; Pricing 1: 1=0.10000, 10=0.01400, 100=0.00580, 1000=0.00262, 2500=0.00228, 5000=0.00188 (USD); Resistance Tolerance: 1%; Resistor Type: Thick Film; RoHS: Yes; RoHS Compliant: Yes; Series: RC; Stock 1: 662020; Supplier 1: Digi-Key; Supplier Part Number 1: 311-360KLRCT-ND; Termination: SMD/SMT; Tolerance: 1%; Voltage Rating (DC): 50V; Width: 0.02inch
R8 = RC0402FR-0756KL: RES SMD 56K OHM 1% 1/16W 0402; Manufacturer: Yageo; Max Power Dissipation: 63mW; Power Rating: 62.5mW; Resistance: 56kR; Temperature Coefficient: 100ppm/°C; Voltage Rating: 50V; Case Code (Imperial): 0402; Case/Package: 0402; Category: Chip SMD Resistors; Component Kind: Standard; ComponentLink1Description: https://datasheet.ciiva.com/46412/1018594-46412840.pdf?src-supplier=Element14; ComponentLink1URL: https://datasheet.ciiva.com/46412/1018594-46412840.pdf?src-supplier=Element14; ComponentLink2Description: https://datasheet.ciiva.com/2453/pyu-r-int-thick-7-2453479.pdf?src-supplier=Digi-Key; ComponentLink2URL: https://datasheet.ciiva.com/2453/pyu-r-int-thick-7-2453479.pdf?src-supplier=Digi-Key; ComponentLink3Description: https://datasheet.ciiva.com/2453/pyu-r-int-thick-7-2453480.pdf?src-supplier=Digi-Key; ComponentLink3URL: https://datasheet.ciiva.com/2453/pyu-r-int-thick-7-2453480.pdf?src-supplier=Digi-Key; ComponentLink4Description: https://datasheet.ciiva.com/2453/pyu-r-int-thick-7-2453478.pdf?src-supplier=Digi-Key; ComponentLink4URL: https://datasheet.ciiva.com/2453/pyu-r-int-thick-7-2453478.pdf?src-supplier=Digi-Key; ComponentLink5Description: https://datasheet.ciiva.com/5558/pyu-rc0402-51-rohs-l-6-r-5558279.pdf?src-supplier=Mouser; ComponentLink5URL: https://datasheet.ciiva.com/5558/pyu-rc0402-51-rohs-l-6-r-5558279.pdf?src-supplier=Mouser; ComponentLink6Description: https://datasheet.ciiva.com/7850/1018594-7850045.pdf?src-supplier=Element14; ComponentLink6URL: https://datasheet.ciiva.com/7850/1018594-7850045.pdf?src-supplier=Element14; ComponentLink7Description: https://datasheet.ciiva.com/7850/1018594-7850044.pdf?src-supplier=Element14; ComponentLink7URL: https://datasheet.ciiva.com/7850/1018594-7850044.pdf?src-supplier=Element14; Construction: Rectangular; Depth: 0.5mm; Features: Moisture Resistant; Height: 0.016inch; Lead Free: Lead Free; Length: 1mm; Library Name: Schlib-master.SchLib; Manufacturer Part Number: RC0402FR-0756KL; Max Operating Temperature: 155°C; Military Standard: Not; Min Operating Temperature: -55°C; Number of Terminations: 2; Package Quantity: 1; Packaging: Tape and Reel; Pricing 1: 1=0.10000, 10=0.01600, 100=0.00660, 1000=0.00299, 2500=0.00259, 5000=0.00214 (USD); REACH SVHC: No SVHC; Resistance Tolerance: 1%; Resistor Type: Thick Film; RoHS: Yes; RoHS Compliant: Yes; Series: RC; Stock 1: 724545; Supplier 1: Digi-Key; Supplier Part Number 1: 311-56.0KLRCT-ND; Technology: THICK FILM; Termination: SMD/SMT; Tolerance: 1%; Width: 0.02inch; Working Voltage: 50V
R14 = RC0402FR-07510RL: RES SMD 510 OHM 1% 1/16W 0402; Manufacturer: Yageo; Category 1: Resistors; Category 2: Chip Resistor - Surface Mount; Max Power Dissipation: 63mW; Temperature Coefficient: 100ppm/°C; Voltage Rating: 50V; Case Code (Imperial): 0402; Case/Package: 0402; Component Kind: Standard; ComponentLink1Description: https://datasheet.ciiva.com/17063/pyu-rc0402-51-rohs-l-6-r-17063430.pdf?src-supplier=Future+Electronics; ComponentLink1URL: https://datasheet.ciiva.com/17063/pyu-rc0402-51-rohs-l-6-r-17063430.pdf?src-supplier=Future+Electronics; ComponentLink2Description: https://datasheet.ciiva.com/2453/pyu-r-int-thick-7-2453397.pdf?src-supplier=Digi-Key; ComponentLink2URL: https://datasheet.ciiva.com/2453/pyu-r-int-thick-7-2453397.pdf?src-supplier=Digi-Key; ComponentLink3Description: https://datasheet.ciiva.com/2453/pyu-r-int-thick-7-2453398.pdf?src-supplier=Digi-Key; ComponentLink3URL: https://datasheet.ciiva.com/2453/pyu-r-int-thick-7-2453398.pdf?src-supplier=Digi-Key; ComponentLink4Description: https://datasheet.ciiva.com/2453/pyu-r-int-thick-7-2453399.pdf?src-supplier=Digi-Key; ComponentLink4URL: https://datasheet.ciiva.com/2453/pyu-r-int-thick-7-2453399.pdf?src-supplier=Digi-Key; Composition: Thick Film; Depth: 0.5mm; Features: Moisture Resistant; Height: 0.016inch; Lead Free: Lead Free; Length: 1mm; Library Name: Schlib-master.SchLib; Manufacturer 1: Yageo; Manufacturer Part Number: RC0402FR-07510RL; Manufacturer Part Number 1: RC0402FR-07510RL; Max Operating Temperature: 155°C; Military Standard: Not; Min Operating Temperature: -55°C; Mount: Surface Mount; Number of Terminations: 2; Package Quantity: 1; Packaging: Tape and Reel; Power Rating: 63mW; Pricing 1: 1=0.10000, 10=0.01600, 100=0.00640, 1000=0.00289, 2500=0.00251, 5000=0.00207 (USD); REACH SVHC: Unknown; Resistance: 510R; RoHS: Yes; Stock 1: 458379; Supplier 1: Digi-Key; Supplier Part Number 1: 311-510LRCT-ND; Termination: SMD/SMT; Tolerance: 1%; Voltage Rating (DC): 50V; Weight: 2.3E-05oz; Width: 0.5mm
SW1 SW2 = PTS810SJM250SMTRLFS: SWITCH TACTILE SPST-NO 0.05A 16V; Manufacturer: ITT C&K; Actuator Type: Standard; Current Rating: 50mA; Illumination Type: Non-Illuminated; Mechanical Life: 150000Cycles; Operating Force: 160gf; Switch Function: Off-Mom; Switch Travel: 0.15mm; Throw Configuration: SPST; Case/Package: SMD/SMT; Category: Tactile Switches; Color: Black; Component Kind: Standard; ComponentLink1Description: https://datasheet.ciiva.com/24082/pts810-4mar13-248115-24082262.pdf?src-supplier=Mouser; ComponentLink1URL: https://datasheet.ciiva.com/24082/pts810-4mar13-248115-24082262.pdf?src-supplier=Mouser; ComponentLink2Description: https://datasheet.ciiva.com/18514/pts810-4mar13-18514059.pdf?src-supplier=Digi-Key; ComponentLink2URL: https://datasheet.ciiva.com/18514/pts810-4mar13-18514059.pdf?src-supplier=Digi-Key; ComponentLink3Description: https://datasheet.ciiva.com/18514/pts810-4mar13-18514060.pdf?src-supplier=Digi-Key; ComponentLink3URL: https://datasheet.ciiva.com/18514/pts810-4mar13-18514060.pdf?src-supplier=Digi-Key; ComponentLink4Description: https://datasheet.ciiva.com/18514/pts810-4mar13-18514058.pdf?src-supplier=Digi-Key; ComponentLink4URL: https://datasheet.ciiva.com/18514/pts810-4mar13-18514058.pdf?src-supplier=Digi-Key; Contact Plating: Silver; Depth: 4.6mm; Lead Free: Lead Free; Length: 3.2mm; Library Name: Schlib-master.SchLib; Manufacturer Part Number: PTS810SJM250SMTRLFS; Max Current Rating: 50mA; Max Operating Temperature: 85°C; Min Operating Temperature: -40°C; Mount: Surface Mount; Orientation: Straight; Package Quantity: 2900; Packaging: Tape and Reel; Pricing 1: 1=0.36000, 10=0.34400, 25=0.32440, 100=0.28150, 250=0.25536, 500=0.23754, 1000=0.21379 (USD); RoHS: Yes; RoHS Compliant: Yes; Stock 1: 536224; Supplier 1: Digi-Key; Supplier Part Number 1: CKN10502CT-ND; Termination: J-Bend; Voltage Rating (DC): 16V
U1 = BQ25180YBGR: IC 1-A LI-ION IC PROGRAMMABLE CHARG; Manufacturer: Texas Instruments; Component Kind: Standard; ComponentLink1Description: https://www.ti.com/lit/ds/symlink/bq25180.pdf?ts=1664107697804&ref_url=https%253A%252F%252Fwww.ti.com%252Fproduct%252FBQ25180; ComponentLink1URL: https://www.ti.com/lit/ds/symlink/bq25180.pdf?ts=1664107697804&ref_url=https%253A%252F%252Fwww.ti.com%252Fproduct%252FBQ25180; Library Name: Schlib-master.SchLib; Manufacturer Part Number: BQ25180YBGR; Pricing 1: 1=2.78000, 10=2.50000, 25=2.35840, 100=2.00940, 250=1.88680, 500=1.65096, 1000=1.42400 (USD); RoHS: No; Stock 1: 5326; Supplier 1: Digi-Key; Supplier Part Number 1: 296-BQ25180YBGRCT-ND
U2 = 74LVC4066BQ,115: IC SWITCH QUAD SPST 14DHVQFN; Manufacturer: Nexperia; Category: Decoders and Multiplexers; Component Kind: Standard; ComponentLink1Description: https://assets.nexperia.com/documents/data-sheet/74LVC4066.pdf?src-supplier=Digi-Key; ComponentLink1URL: https://assets.nexperia.com/documents/data-sheet/74LVC4066.pdf?src-supplier=Digi-Key; Library Name: Schlib-master.SchLib; Manufacturer Part Number: 74LVC4066BQ,115; Pricing 1: 1=0.67000, 10=0.59300, 25=0.55720, 100=0.45480, 250=0.42248, 500=0.35956, 1000=0.28764 (USD); RoHS: Yes; RoHS Compliant: Yes; Stock 1: 53790; Supplier 1: Digi-Key; Supplier Part Number 1: 1727-3499-1-ND
U3 = NCP167BMX330TBG: IC REG LINEAR 3.3V 700MA 4XDFN; Manufacturer: ON Semiconductor; Category: Voltage Regulators - Linear; Component Kind: Standard; ComponentLink1Description: http://www.farnell.com/datasheets/2711391.pdf?src-supplier=Farnell; ComponentLink1URL: http://www.farnell.com/datasheets/2711391.pdf?src-supplier=Farnell; ComponentLink2Description: https://www.onsemi.com/pub/Collateral/NCP167-D.PDF?src-supplier=Digi-Key; ComponentLink2URL: https://www.onsemi.com/pub/Collateral/NCP167-D.PDF?src-supplier=Digi-Key; ComponentLink3Description: http://www.farnell.com/datasheets/2711391.pdf?src-supplier=Newark; ComponentLink3URL: http://www.farnell.com/datasheets/2711391.pdf?src-supplier=Newark; Library Name: Schlib-master.SchLib; Manufacturer Part Number: NCP167BMX330TBG; Pricing 1: 1=0.61000, 10=0.52500, 25=0.49000, 100=0.39210, 250=0.36408, 500=0.30806, 1000=0.23804 (USD); RoHS: Yes; RoHS Compliant: Yes; Stock 1: 222045; Supplier 1: Digi-Key; Supplier Part Number 1: NCP167BMX330TBGOSCT-ND
U4 = ESP32-S3FN8: IC RF TXRX+MCU BLUETOOTH WIFI; Manufacturer: Espressif Systems; Component Kind: Standard; Library Name: Schlib-master.SchLib; Manufacturer Part Number: ESP32-S3FN8; Pricing 1: 1=2.28000, 10=2.28000, 50=2.28000, 100=2.28000, 1000=2.28000, 10000=2.28000 (USD); RoHS: No; Stock 1: 12211; Supplier 1: Mouser; Supplier Part Number 1: 356-ESP32-S3FN8
X1 = 7D040000H01: CRYSTAL 40.0000MHZ 9PF SMD; Manufacturer: HD; Frequency Stability: ±20ppm; Frequency Tolerance: ±10ppm; Category: Crystals; Component Kind: Standard; ComponentLink1Description: https://www.lcsc.com/product-detail/Crystals_HD-7D040000H01_C648972.html; ComponentLink1URL: https://www.lcsc.com/product-detail/Crystals_HD-7D040000H01_C648972.html; Frequency: 40MHz; Library Name: Schlib-master.SchLib; Manufacturer Part Number: 7D040000H01; RoHS: Yes
J2 J3 = Double_Header_1x11_2.54: Description: Double_Header_1x11_2.54; Library Name: Schlib-master.SchLib
